# Altium schematic sheet STM32F407VET6.SchDoc(STM32F407VET6)
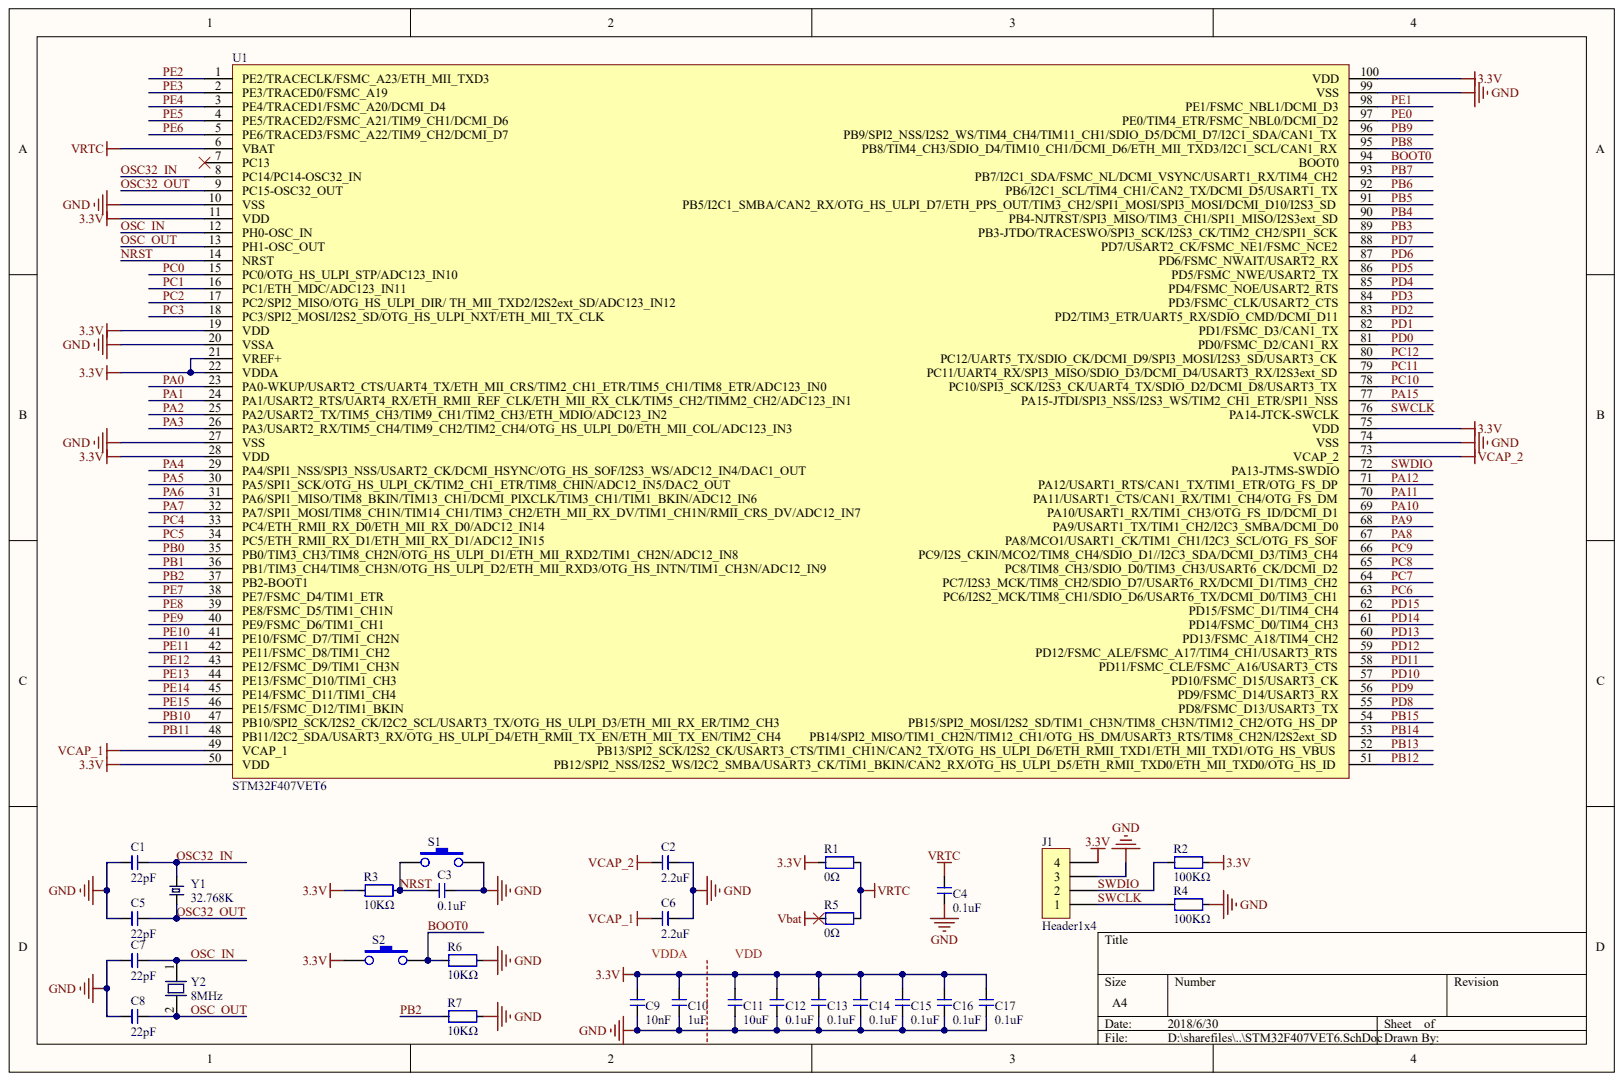
[diagram p0: STM32F407VET6.SchDoc]

## Parts
C1  value 22pF  (hidden comment: Cap)
C2  value 2.2uF  (hidden comment: Cap)
C3  value 0.1uF  (hidden comment: Cap)
C4  value 0.1uF  (hidden comment: Cap)
C5  value 22pF  (hidden comment: Cap)
C6  value 2.2uF  (hidden comment: Cap)
C7  value 22pF  (hidden comment: Cap)
C8  value 22pF  (hidden comment: Cap)
C9  value 10nF  (hidden comment: Cap)
C10  value 1uF  (hidden comment: Cap)
C11  value 10uF  (hidden comment: Cap)
C12  value 0.1uF  (hidden comment: Cap)
C13  value 0.1uF  (hidden comment: Cap)
C14  value 0.1uF  (hidden comment: Cap)
C15  value 0.1uF  (hidden comment: Cap)
C16  value 0.1uF  (hidden comment: Cap)
C17  value 0.1uF  (hidden comment: Cap)
J1  Header1x4
R1  value 0Ω  (hidden comment: Res)
R2  value 100KΩ  (hidden comment: Res)
R3  value 10KΩ  (hidden comment: Res)
R4  value 100KΩ  (hidden comment: Res)
R5  value 0Ω  (hidden comment: Res)
R6  value 10KΩ  (hidden comment: Res)
R7  value 10KΩ  (hidden comment: Res)
S1  KeySMT  (value not drawn)
S2  KeySMT  (value not drawn)
U1  STM32F407VET6
Y1  32.768K
Y2  value 8MHz  (hidden comment: 12M)

## Nets
3.3V: C9.2 C10.2 C11.2 C12.2 C13.2 C14.2 C15.2 C16.2 C17.2 J1.4 R1.1 R2.2 R3.2 S2.1 U1.11(VDD) U1.19(VDD) U1.21(VREF+) U1.22(VDDA) U1.28(VDD) U1.50(VDD) U1.75(VDD) U1.100(VDD)
GND: C1.1 C2.1 C3.1 C4.1 C5.1 C6.1 C7.1 C8.1 C9.1 C10.1 C11.1 C12.1 C13.1 C14.1 C15.1 C16.1 C17.1 J1.3 R4.2 R6.1 R7.1 S1.2 U1.10(VSS) U1.20(VSSA) U1.27(VSS) U1.74(VSS) U1.99(VSS)
VBAT: R5.1
VCAP_1: C6.2 U1.49(VCAP_1)
VCAP_2: C2.2 U1.73(VCAP_2)
VRTC: C4.2 R1.2 R5.2 U1.6(VBAT)
BOOT0: R6.2 S2.2 U1.94(BOOT0)
NRST: C3.2 R3.1 S1.1 U1.14(NRST)
OSC32_IN: C1.2 U1.8(PC14/PC14-OSC32_IN) Y1.2
OSC32_OUT: C5.2 U1.9(PC15-OSC32_OUT) Y1.1
OSC_IN: C7.2 U1.12(PH0-OSC_IN) Y2.1
OSC_OUT: C8.2 U1.13(PH1-OSC_OUT) Y2.2
PA0: U1.23(PA0-WKUP/USART2_CTS/UART4_TX/ETH_MII_CRS/TIM2_CH1_ETR/TIM5_CH1/TIM8_ETR/ADC123_IN0)
PA1: U1.24(PA1/USART2_RTS/UART4_RX/ETH_RMII_REF_CLK/ETH_MII_RX_CLK/TIM5_CH2/TIMM2_CH2/ADC123_IN1)
PA2: U1.25(PA2/USART2_TX/TIM5_CH3/TIM9_CH1/TIM2_CH3/ETH_MDIO/ADC123_IN2)
PA3: U1.26(PA3/USART2_RX/TIM5_CH4/TIM9_CH2/TIM2_CH4/OTG_HS_ULPI_D0/ETH_MII_COL/ADC123_IN3)
PA4: U1.29(PA4/SPI1_NSS/SPI3_NSS/USART2_CK/DCMI_HSYNC/OTG_HS_SOF/I2S3_WS/ADC12_IN4/DAC1_OUT)
PA5: U1.30(PA5/SPI1_SCK/OTG_HS_ULPI_CK/TIM2_CH1_ETR/TIM8_CHIN/ADC12_IN5/DAC2_OUT)
PA6: U1.31(PA6/SPI1_MISO/TIM8_BKIN/TIM13_CH1/DCMI_PIXCLK/TIM3_CH1/TIM1_BKIN/ADC12_IN6)
PA7: U1.32(PA7/SPI1_MOSI/TIM8_CH1N/TIM14_CH1/TIM3_CH2/ETH_MII_RX_DV/TIM1_CH1N/RMII_CRS_DV/ADC12_IN7)
PA8: U1.67(PA8/MCO1/USART1_CK/TIM1_CH1/I2C3_SCL/OTG_FS_SOF)
PA9: U1.68(PA9/USART1_TX/TIM1_CH2/I2C3_SMBA/DCMI_D0)
PA10: U1.69(PA10/USART1_RX/TIM1_CH3/OTG_FS_ID/DCMI_D1)
PA11: U1.70(PA11/USART1_CTS/CAN1_RX/TIM1_CH4/OTG_FS_DM)
PA12: U1.71(PA12/USART1_RTS/CAN1_TX/TIM1_ETR/OTG_FS_DP)
PA15: U1.77(PA15-JTDI/SPI3_NSS/I2S3_WS/TIM2_CH1_ETR/SPI1_NSS)
PB0: U1.35(PB0/TIM3_CH3/TIM8_CH2N/OTG_HS_ULPI_D1/ETH_MII_RXD2/TIM1_CH2N/ADC12_IN8)
PB1: U1.36(PB1/TIM3_CH4/TIM8_CH3N/OTG_HS_ULPI_D2/ETH_MII_RXD3/OTG_HS_INTN/TIM1_CH3N/ADC12_IN9)
PB2: R7.2 U1.37(PB2-BOOT1)
PB3: U1.89(PB3-JTDO/TRACESWO/SPI3_SCK/I2S3_CK/TIM2_CH2/SPI1_SCK)
PB4: U1.90(PB4-NJTRST/SPI3_MISO/TIM3_CH1/SPI1_MISO/I2S3ext_SD)
PB5: U1.91(PB5/I2C1_SMBA/CAN2_RX/OTG_HS_ULPI_D7/ETH_PPS_OUT/TIM3_CH2/SPI1_MOSI/SPI3_MOSI/DCMI_D10/I2S3_SD)
PB6: U1.92(PB6/I2C1_SCL/TIM4_CH1/CAN2_TX/DCMI_D5/USART1_TX)
PB7: U1.93(PB7/I2C1_SDA/FSMC_NL/DCMI_VSYNC/USART1_RX/TIM4_CH2)
PB8: U1.95(PB8/TIM4_CH3/SDIO_D4/TIM10_CH1/DCMI_D6/ETH_MII_TXD3/I2C1_SCL/CAN1_RX)
PB9: U1.96(PB9/SPI2_NSS/I2S2_WS/TIM4_CH4/TIM11_CH1/SDIO_D5/DCMI_D7/I2C1_SDA/CAN1_TX)
PB10: U1.47(PB10/SPI2_SCK/I2S2_CK/I2C2_SCL/USART3_TX/OTG_HS_ULPI_D3/ETH_MII_RX_ER/TIM2_CH3)
PB11: U1.48(PB11/I2C2_SDA/USART3_RX/OTG_HS_ULPI_D4/ETH_RMII_TX_EN/ETH_MII_TX_EN/TIM2_CH4)
PB12: U1.51(PB12/SPI2_NSS/I2S2_WS/I2C2_SMBA/USART3_CK/TIM1_BKIN/CAN2_RX/OTG_HS_ULPI_D5/ETH_RMII_TXD0/ETH_MII_TXD0/OTG_HS_ID)
PB13: U1.52(PB13/SPI2_SCK/I2S2_CK/USART3_CTS/TIM1_CH1N/CAN2_TX/OTG_HS_ULPI_D6/ETH_RMII_TXD1/ETH_MII_TXD1/OTG_HS_VBUS)
PB14: U1.53(PB14/SPI2_MISO/TIM1_CH2N/TIM12_CH1/OTG_HS_DM/USART3_RTS/TIM8_CH2N/I2S2ext_SD)
PB15: U1.54(PB15/SPI2_MOSI/I2S2_SD/TIM1_CH3N/TIM8_CH3N/TIM12_CH2/OTG_HS_DP)
PC0: U1.15(PC0/OTG_HS_ULPI_STP/ADC123_IN10)
PC1: U1.16(PC1/ETH_MDC/ADC123_IN11)
PC2: U1.17(PC2/SPI2_MISO/OTG_HS_ULPI_DIR/_TH_MII_TXD2/I2S2ext_SD/ADC123_IN12)
PC3: U1.18(PC3/SPI2_MOSI/I2S2_SD/OTG_HS_ULPI_NXT/ETH_MII_TX_CLK)
PC4: U1.33(PC4/ETH_RMII_RX_D0/ETH_MII_RX_D0/ADC12_IN14)
PC5: U1.34(PC5/ETH_RMII_RX_D1/ETH_MII_RX_D1/ADC12_IN15)
PC6: U1.63(PC6/I2S2_MCK/TIM8_CH1/SDIO_D6/USART6_TX/DCMI_D0/TIM3_CH1)
PC7: U1.64(PC7/I2S3_MCK/TIM8_CH2/SDIO_D7/USART6_RX/DCMI_D1/TIM3_CH2)
PC8: U1.65(PC8/TIM8_CH3/SDIO_D0/TIM3_CH3/USART6_CK/DCMI_D2)
PC9: U1.66(PC9/I2S_CKIN/MCO2/TIM8_CH4/SDIO_D1//I2C3_SDA/DCMI_D3/TIM3_CH4)
PC10: U1.78(PC10/SPI3_SCK/I2S3_CK/UART4_TX/SDIO_D2/DCMI_D8/USART3_TX)
PC11: U1.79(PC11/UART4_RX/SPI3_MISO/SDIO_D3/DCMI_D4/USART3_RX/I2S3ext_SD)
PC12: U1.80(PC12/UART5_TX/SDIO_CK/DCMI_D9/SPI3_MOSI/I2S3_SD/USART3_CK)
PD0: U1.81(PD0/FSMC_D2/CAN1_RX)
PD1: U1.82(PD1/FSMC_D3/CAN1_TX)
PD2: U1.83(PD2/TIM3_ETR/UART5_RX/SDIO_CMD/DCMI_D11)
PD3: U1.84(PD3/FSMC_CLK/USART2_CTS)
PD4: U1.85(PD4/FSMC_NOE/USART2_RTS)
PD5: U1.86(PD5/FSMC_NWE/USART2_TX)
PD6: U1.87(PD6/FSMC_NWAIT/USART2_RX)
PD7: U1.88(PD7/USART2_CK/FSMC_NE1/FSMC_NCE2)
PD8: U1.55(PD8/FSMC_D13/USART3_TX)
PD9: U1.56(PD9/FSMC_D14/USART3_RX)
PD10: U1.57(PD10/FSMC_D15/USART3_CK)
PD11: U1.58(PD11/FSMC_CLE/FSMC_A16/USART3_CTS)
PD12: U1.59(PD12/FSMC_ALE/FSMC_A17/TIM4_CH1/USART3_RTS)
PD13: U1.60(PD13/FSMC_A18/TIM4_CH2)
PD14: U1.61(PD14/FSMC_D0/TIM4_CH3)
PD15: U1.62(PD15/FSMC_D1/TIM4_CH4)
PE0: U1.97(PE0/TIM4_ETR/FSMC_NBL0/DCMI_D2)
PE1: U1.98(PE1/FSMC_NBL1/DCMI_D3)
PE2: U1.1(PE2/TRACECLK/FSMC_A23/ETH_MII_TXD3)
PE3: U1.2(PE3/TRACED0/FSMC_A19)
PE4: U1.3(PE4/TRACED1/FSMC_A20/DCMI_D4)
PE5: U1.4(PE5/TRACED2/FSMC_A21/TIM9_CH1/DCMI_D6)
PE6: U1.5(PE6/TRACED3/FSMC_A22/TIM9_CH2/DCMI_D7)
PE7: U1.38(PE7/FSMC_D4/TIM1_ETR)
PE8: U1.39(PE8/FSMC_D5/TIM1_CH1N)
PE9: U1.40(PE9/FSMC_D6/TIM1_CH1)
PE10: U1.41(PE10/FSMC_D7/TIM1_CH2N)
PE11: U1.42(PE11/FSMC_D8/TIM1_CH2)
PE12: U1.43(PE12/FSMC_D9/TIM1_CH3N)
PE13: U1.44(PE13/FSMC_D10/TIM1_CH3)
PE14: U1.45(PE14/FSMC_D11/TIM1_CH4)
PE15: U1.46(PE15/FSMC_D12/TIM1_BKIN)
SWCLK: J1.1 R4.1 U1.76(PA14-JTCK-SWCLK)
SWDIO: J1.2 R2.1 U1.72(PA13-JTMS-SWDIO)

Not connected: U1 7(PC13)

## Library data of the parts
C1-C17 = (no symbol name): 插位: SMT; 属性: ±10%/50V; 编码: *
R1-R7 = (no symbol name): 插位: SMT; 属性: ±1%/1/10W; 编码: *
S1 S2 U1 Y2 = (no symbol name): 插位: SMT; 编码: *
  S1 S2 U1: Value: *
Y1 = (no symbol name): 插位: HI; 编码: *; Value: 32.768K
